# Altium schematic sheet Voltage_Battery_Measure.SchDoc
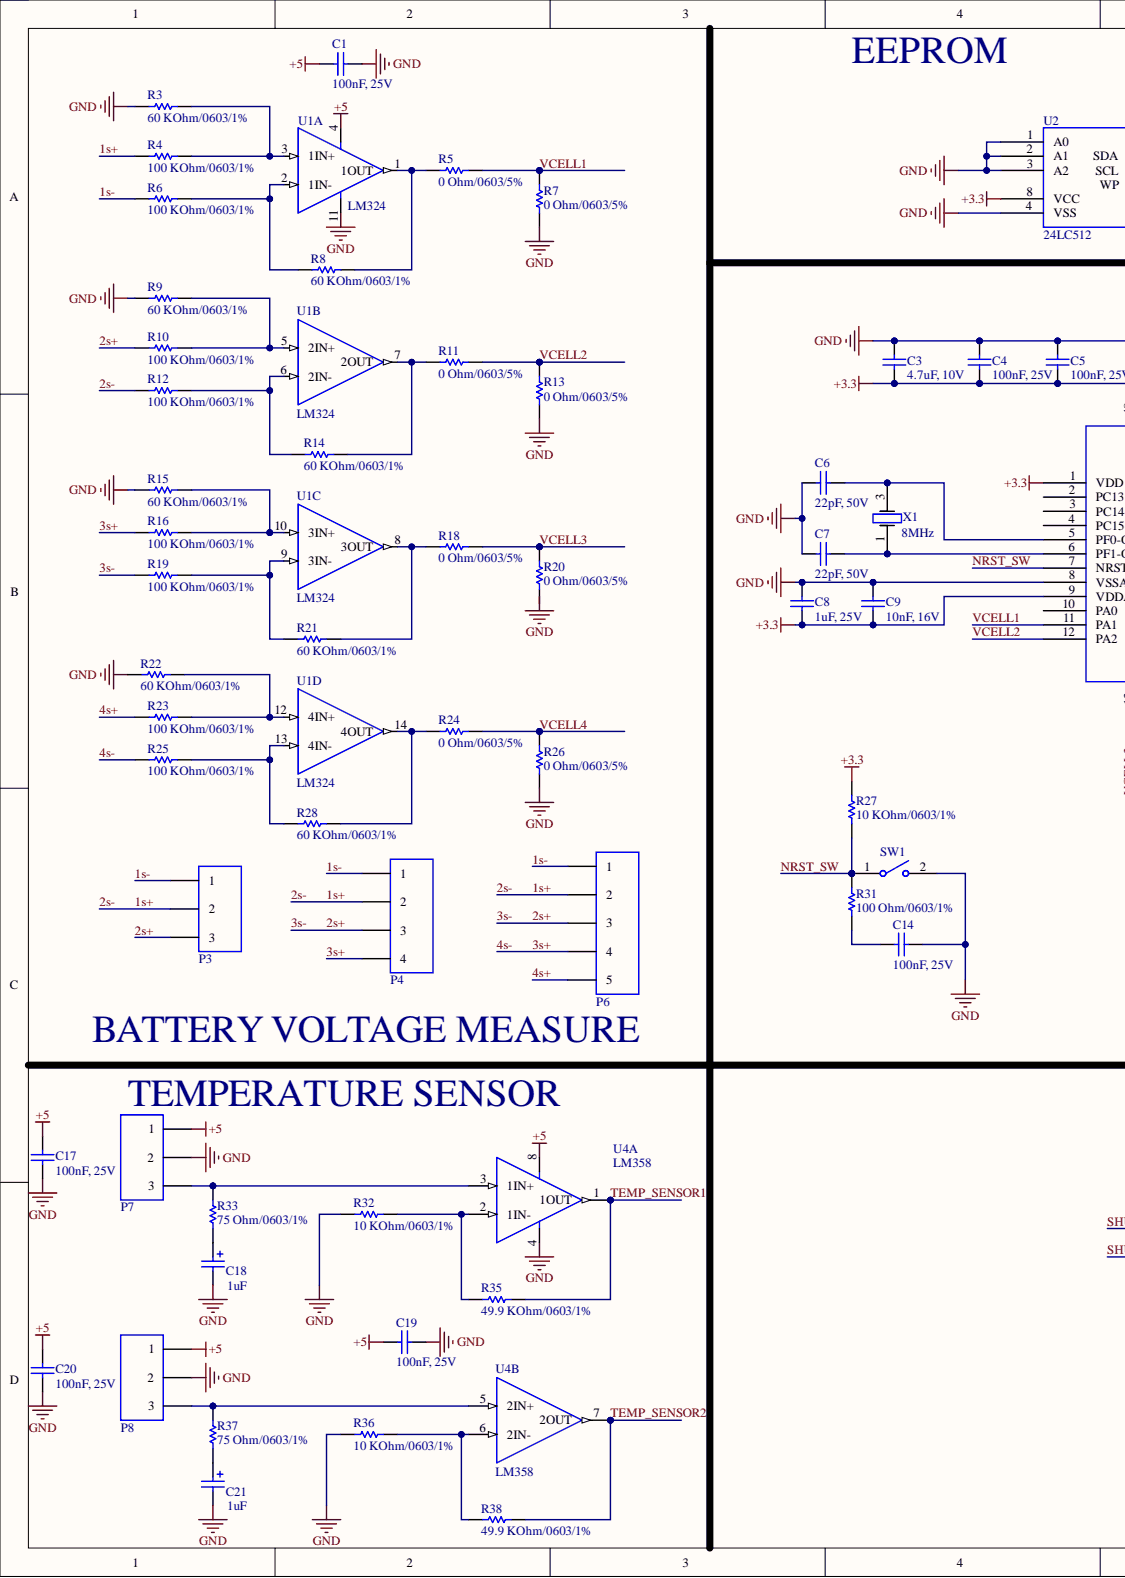
[diagram p0: Voltage_Battery_Measure.SchDoc, left]
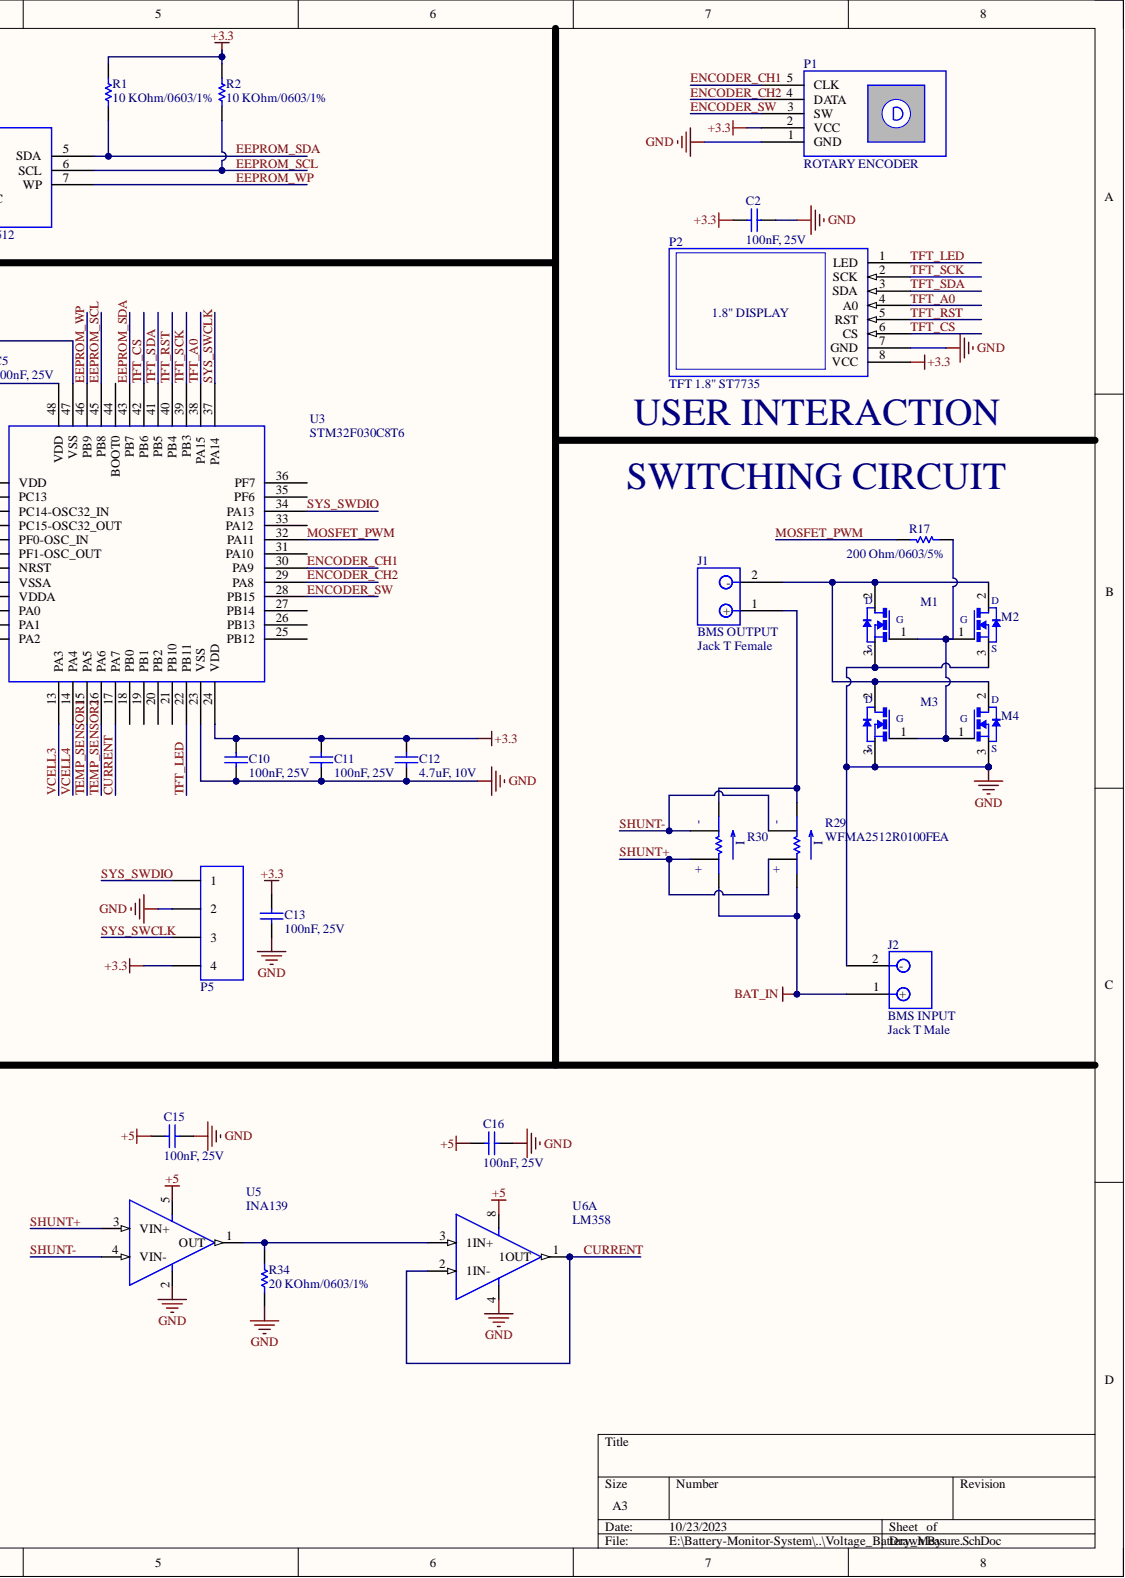
[diagram p1: Voltage_Battery_Measure.SchDoc, right]

## Parts
C1  100nF, 25V  [WCAP-CSGP_0603_H=0.8mm_Reflow]
C2  100nF, 25V  [WCAP-CSGP_0603_H=0.8mm_Reflow]
C3  4.7uF, 10V  [WCAP-CSGP_0603_H=0.8mm_Reflow]
C4  100nF, 25V  [WCAP-CSGP_0603_H=0.8mm_Reflow]
C5  100nF, 25V  [WCAP-CSGP_0603_H=0.8mm_Reflow]
C6  22pF, 50V  [WCAP-CSGP_0603_H=0.8mm_Reflow]
C7  22pF, 50V  [WCAP-CSGP_0603_H=0.8mm_Reflow]
C8  1uF, 25V  [WCAP-CSGP_0603_H=0.8mm_Reflow]
C9  10nF, 16V  [WCAP-CSGP_0603_H=0.8mm_Reflow]
C10  100nF, 25V  [WCAP-CSGP_0603_H=0.8mm_Reflow]
C11  100nF, 25V  [WCAP-CSGP_0603_H=0.8mm_Reflow]
C12  4.7uF, 10V  [WCAP-CSGP_0603_H=0.8mm_Reflow]
C13  100nF, 25V  [WCAP-CSGP_0603_H=0.8mm_Reflow]
C14  100nF, 25V  [WCAP-CSGP_0603_H=0.8mm_Reflow]
C15  100nF, 25V  [WCAP-CSGP_0603_H=0.8mm_Reflow]
C16  100nF, 25V  [WCAP-CSGP_0603_H=0.8mm_Reflow]
C17  100nF, 25V  [WCAP-CSGP_0603_H=0.8mm_Reflow]
C18  1uF  [WCAP-ATG8_D5H11]
C19  100nF, 25V  [WCAP-CSGP_0603_H=0.8mm_Reflow]
C20  100nF, 25V  [WCAP-CSGP_0603_H=0.8mm_Reflow]
C21  1uF  [WCAP-ATG8_D5H11]
J1  BMS OUTPUT  value Jack T Female  [Jack T - FEMALE]
J2  BMS INPUT  value Jack T Male  [Jack T - Male]
M1  AOD472  [TO252-3]  (value not drawn)
M2  AOD472  [TO252-3]  (value not drawn)
M3  AOD472  [TO252-3]  (value not drawn)
M4  AOD472  [TO252-3]  (value not drawn)
P1  Rotary Encoder  [Rotary Encoder]
P2  TFT 1.8" ST7735  [JP8]
P3  Header 3H  [JP3H]  (value not drawn)
P4  Header 4H  [JP4H]  (value not drawn)
P5  Header 4H  [JP4H]  (value not drawn)
P6  Header 5H  [JP5H]  (value not drawn)
P7  Header 3H  [JP3H]  (value not drawn)
P8  Header 3H  [JP3H]  (value not drawn)
R1  value 10 KOhm/0603/1%  [R0603]  (hidden comment: Resistor 10 KOhm 0603 1%)
R2  value 10 KOhm/0603/1%  [R0603]  (hidden comment: Resistor 10 KOhm 0603 1%)
R3  value 60 KOhm/0603/1%  [R0603]  (hidden comment: Resistor 60 KOhm 0603 1%)
R4  value 100 KOhm/0603/1%  [R0603]  (hidden comment: Resistor 100 KOhm 0603 1%)
R5  value 0 Ohm/0603/5%  [R0603]  (hidden comment: Resistor 0 Ohm 0603 5%)
R6  value 100 KOhm/0603/1%  [R0603]  (hidden comment: Resistor 100 KOhm 0603 1%)
R7  value 0 Ohm/0603/5%  [R0603]  (hidden comment: Resistor 0 Ohm 0603 5%)
R8  value 60 KOhm/0603/1%  [R0603]  (hidden comment: Resistor 60 KOhm 0603 1%)
R9  value 60 KOhm/0603/1%  [R0603]  (hidden comment: Resistor 60 KOhm 0603 1%)
R10  value 100 KOhm/0603/1%  [R0603]  (hidden comment: Resistor 100 KOhm 0603 1%)
R11  value 0 Ohm/0603/5%  [R0603]  (hidden comment: Resistor 0 Ohm 0603 5%)
R12  value 100 KOhm/0603/1%  [R0603]  (hidden comment: Resistor 100 KOhm 0603 1%)
R13  value 0 Ohm/0603/5%  [R0603]  (hidden comment: Resistor 0 Ohm 0603 5%)
R14  value 60 KOhm/0603/1%  [R0603]  (hidden comment: Resistor 60 KOhm 0603 1%)
R15  value 60 KOhm/0603/1%  [R0603]  (hidden comment: Resistor 60 KOhm 0603 1%)
R16  value 100 KOhm/0603/1%  [R0603]  (hidden comment: Resistor 100 KOhm 0603 1%)
R17  value 200 Ohm/0603/5%  [R0603]  (hidden comment: Resistor 200 Ohm 0603 5%)
R18  value 0 Ohm/0603/5%  [R0603]  (hidden comment: Resistor 0 Ohm 0603 5%)
R19  value 100 KOhm/0603/1%  [R0603]  (hidden comment: Resistor 100 KOhm 0603 1%)
R20  value 0 Ohm/0603/5%  [R0603]  (hidden comment: Resistor 0 Ohm 0603 5%)
R21  value 60 KOhm/0603/1%  [R0603]  (hidden comment: Resistor 60 KOhm 0603 1%)
R22  value 60 KOhm/0603/1%  [R0603]  (hidden comment: Resistor 60 KOhm 0603 1%)
R23  value 100 KOhm/0603/1%  [R0603]  (hidden comment: Resistor 100 KOhm 0603 1%)
R24  value 0 Ohm/0603/5%  [R0603]  (hidden comment: Resistor 0 Ohm 0603 5%)
R25  value 100 KOhm/0603/1%  [R0603]  (hidden comment: Resistor 100 KOhm 0603 1%)
R26  value 0 Ohm/0603/5%  [R0603]  (hidden comment: Resistor 0 Ohm 0603 5%)
R27  value 10 KOhm/0603/1%  [R0603]  (hidden comment: Resistor 10 KOhm 0603 1%)
R28  value 60 KOhm/0603/1%  [R0603]  (hidden comment: Resistor 60 KOhm 0603 1%)
R29  value WFMA2512R0100FEA  [R2512]  (hidden comment: Current Sense Resistor)
R30  Current Sense Resistor  [R2512]  (value not drawn)
R31  value 100 Ohm/0603/1%  [R0603]  (hidden comment: Resistor 100 Ohm 0603 1%)
R32  value 10 KOhm/0603/1%  [R0603]  (hidden comment: Resistor 10 KOhm 0603 1%)
R33  value 75 Ohm/0603/1%  [R0603]  (hidden comment: Resistor 75 Ohm 0603 1%)
R34  value 20 KOhm/0603/1%  [R0603]  (hidden comment: Resistor 20 KOhm 0603 1%)
R35  value 49.9 KOhm/0603/1%  [R0603]  (hidden comment: Resistor 49.9 KOhm 0603 1%)
R36  value 10 KOhm/0603/1%  [R0603]  (hidden comment: Resistor 10 KOhm 0603 1%)
R37  value 75 Ohm/0603/1%  [R0603]  (hidden comment: Resistor 75 Ohm 0603 1%)
R38  value 49.9 KOhm/0603/1%  [R0603]  (hidden comment: Resistor 49.9 KOhm 0603 1%)
SW1  434123050816  [WS-TASV_L6W3.5H5_4341x30508x6]  (value not drawn)
U1  LM324  [SOIC-14]  (parts A,B,C,D)
U2  value 24LC512  [SOIC-8]  (hidden comment: 24LCxx)
U3  STM32F030C8T6  [48-LQFP]
U4  LM358  [SOIC-8]  (parts A,B)
U5  INA139  [SOT-23]
U6  LM358  [SOIC-8]  (part A)
X1  value 8MHz  [XTAL 3225]  (hidden comment: XTAL)

## Nets
+3.3: C2.2 C3.1 C4.1 C5.1 C8.1 C9.1 C10.2 C11.2 C12.2 C13.2 P1.2(VCC) P2.8(VCC) P5.4 R1.2 R2.2 R27.2 U2.8(VCC) U3.1(VDD) U3.9(VDDA) U3.24(VDD) U3.48(VDD)
+5: C1.2 C15.2 C16.2 C17.2 C19.2 C20.2 P7.1 P8.1 U1.4 U4.8 U5.5 U6.8
BAT_IN: J2.1 R29.1 R30.1
GND: C1.1 C2.1 C3.2 C4.2 C5.2 C6.1 C7.1 C8.2 C9.2 C10.1 C11.1 C12.1 C13.1 C14.1 C15.1 C16.1 C17.1 C18.2 C19.1 C20.1 C21.2 J2.2 M1.3 M2.3 M3.3 M4.3 P1.1(GND) P2.7(GND) P5.2 P7.2 P8.2 R3.2 R7.1 R9.2 R13.1 R15.2 R22.2 R26.1 R32.2 R34.1 R36.2 SW1.2 U1.11 U2.1(A0) U2.2(A1) U2.3(A2) U2.4(VSS) U3.8(VSSA) U3.23(VSS) U3.47(VSS) U4.4 U5.2 U6.4
1s+: P3.2 P4.2 P6.2 R4.1 R12.1
1s-: P3.1 P4.1 P6.1 R6.1
2s+: P3.3 P4.3 P6.3 R10.1 R19.1
3s+: P4.4 P6.4 R16.1 R25.1
4s+: P6.5 R23.1
CURRENT: U3.17(PA7) U6.1(1OUT) U6.2(1IN-)
EEPROM_SCL: R2.1 U2.6(SCL) U3.45(PB8)
EEPROM_SDA: R1.1 U2.5(SDA) U3.43(PB7)
EEPROM_WP: U2.7(WP) U3.46(PB9)
ENCODER_CH1: P1.5(CLK) U3.30(PA9)
ENCODER_CH2: P1.4(DATA) U3.29(PA8)
ENCODER_SW: P1.3(SW) U3.28(PB15)
MOSFET_PWM: R17.2 U3.32(PA11)
NRST_SW: R27.1 R31.2 SW1.1 U3.7(NRST)
SHUNT+: R29.1 R30.1 U5.3(VIN+)
SHUNT-: R29.2 R30.2 U5.4(VIN-)
SYS_SWCLK: P5.3 U3.37(PA14)
SYS_SWDIO: P5.1 U3.34(PA13)
TEMP_SENSOR1: R35.2 U3.15(PA5) U4.1(1OUT)
TEMP_SENSOR2: R38.2 U3.16(PA6) U4.7(2OUT)
TFT_A0: P2.4(A0) U3.38(PA15)
TFT_CS: P2.6(CS) U3.42(PB6)
TFT_LED: P2.1(LED) U3.22(PB11)
TFT_RST: P2.5(RST) U3.40(PB4)
TFT_SCK: P2.2(SCK) U3.39(PB3)
TFT_SDA: P2.3(SDA) U3.41(PB5)
VCELL1: R5.2 R7.2 U3.11(PA1)
VCELL2: R11.2 R13.2 U3.12(PA2)
VCELL3: R18.2 R20.2 U3.13(PA3)
VCELL4: R24.2 R26.2 U3.14(PA4)
NetC6_2: C6.2 U3.5(PF0-OSC_IN) X1.3
NetC7_2: C7.2 U3.6(PF1-OSC_OUT) X1.1
NetC14_2: C14.2 R31.1
NetC18_1: C18.1 R33.1
NetC21_1: C21.1 R37.1
NetJ1_1: J1.1 R29.2 R30.2
NetJ1_2: J1.2 M1.2 M2.2 M3.2 M4.2
NetM1_1: M1.1 M2.1 M3.1 M4.1 R17.1
NetP7_3: P7.3 R33.2 U4.3(1IN+)
NetP8_3: P8.3 R37.2 U4.5(2IN+)
NetR3_1: R3.1 R4.2 U1.3(1IN+)
NetR5_1: R5.1 R8.2 U1.1(1OUT)
NetR6_2: R6.2 R8.1 U1.2(1IN-)
NetR9_1: R9.1 R10.2 U1.5(2IN+)
NetR11_1: R11.1 R14.2 U1.7(2OUT)
NetR12_2: R12.2 R14.1 U1.6(2IN-)
NetR15_1: R15.1 R16.2 U1.10(3IN+)
NetR18_1: R18.1 R21.2 U1.8(3OUT)
NetR19_2: R19.2 R21.1 U1.9(3IN-)
NetR22_1: R22.1 R23.2 U1.12(4IN+)
NetR24_1: R24.1 R28.2 U1.14(4OUT)
NetR25_2: R25.2 R28.1 U1.13(4IN-)
NetR32_1: R32.1 R35.1 U4.2(1IN-)
NetR34_2: R34.2 U5.1(OUT) U6.3(1IN+)
NetR36_1: R36.1 R38.1 U4.6(2IN-)

Not connected: R20 1; U3 2(PC13) 3(PC14-OSC32_IN) 4(PC15-OSC32_OUT) 10(PA0) 18(PB0) 19(PB1) 20(PB2) 21(PB10) 25(PB12) 26(PB13) 27(PB14) 31(PA10) 33(PA12) 35(PF6) 36(PF7) 44(BOOT0)

## Library data of the parts
C1 C2 C4 C5 C10 C11 C13-C17 C19 C20 = 885012206071: WCAP-CSGP Multilayer Ceramic Chip Capacitor, General Purpose, size 0603, X7R, 100nF, 25V; Manufacturer: Wurth Elektronik; Capacitance Tolerance: +/-10%; Dissipation Factor: #NAME?; Isolation Resistance: >=5GOhm; Rated Voltage: 25VDC; Technical Reference: X7R0603104K025DFCT10000; Capacitance: 100nF; Ceramic Type: X7R; Component Kind: Standard; ComponentLink1Description: Manufacturer; ComponentLink1URL: http://www.we-online.de; ComponentLink2Description: Datasheet; ComponentLink2URL: https://www.we-online.com/catalog/datasheet/885012206071.pdf; Height: 0.8mm; Library Name: Altium_WCAP-CSGP (rev20a).IntLib; Manufacturer Part Number: 885012206071; Mounting Technology: SMT; Operating Temperature: -55 to +125degC; Packaging: Tape and Reel; Published Date: May 2020; Size: 0603
C3 C12 = 885012106012: WCAP-CSGP Multilayer Ceramic Chip Capacitor, General Purpose, size 0603, X5R, 4.7uF, 10V; Manufacturer: Wurth Elektronik; Capacitance Tolerance: +/-20%; Dissipation Factor: #NAME?; Isolation Resistance: >=0.02GOhm; Rated Voltage: 10VDC; Technical Reference: X5R0603475M010DFCT10000; Capacitance: 4.7uF; Ceramic Type: X5R; Component Kind: Standard; ComponentLink1Description: Manufacturer; ComponentLink1URL: http://www.we-online.de; ComponentLink2Description: Datasheet; ComponentLink2URL: https://www.we-online.com/catalog/datasheet/885012106012.pdf; Height: 0.8mm; Library Name: Altium_WCAP-CSGP (rev20a).IntLib; Manufacturer Part Number: 885012106012; Mounting Technology: SMT; Operating Temperature: -55 to +85degC; Packaging: Tape and Reel; Published Date: May 2020; Size: 0603
C6 C7 = 885012006053: WCAP-CSGP Multilayer Ceramic Chip Capacitor, General Purpose, size 0603, NP0, 22pF, 50V; Manufacturer: Wurth Elektronik; Capacitance Tolerance: +/-5%; Dissipation Factor: Q>=400+20C; Isolation Resistance: >=10GOhm; Rated Voltage: 50VDC; Technical Reference: NP00603220J050DFCT10000; Capacitance: 22pF; Ceramic Type: NP0; Component Kind: Standard; ComponentLink1Description: Manufacturer; ComponentLink1URL: http://www.we-online.de; ComponentLink2Description: Datasheet; ComponentLink2URL: https://www.we-online.com/catalog/datasheet/885012006053.pdf; Height: 0.8mm; Library Name: Altium_WCAP-CSGP (rev20a).IntLib; Manufacturer Part Number: 885012006053; Mounting Technology: SMT; Operating Temperature: -55 to +125degC; Packaging: Tape and Reel; Published Date: May 2020; Size: 0603
C8 = 885012106022: WCAP-CSGP Multilayer Ceramic Chip Capacitor, General Purpose, size 0603, X5R, 1uF, 25V; Manufacturer: Wurth Elektronik; Capacitance Tolerance: +/-20%; Dissipation Factor: #NAME?; Isolation Resistance: >=0.5GOhm; Rated Voltage: 25VDC; Technical Reference: X5R0603105M025DFCT10000; Capacitance: 1uF; Ceramic Type: X5R; Component Kind: Standard; ComponentLink1Description: Manufacturer; ComponentLink1URL: http://www.we-online.de; ComponentLink2Description: Datasheet; ComponentLink2URL: https://www.we-online.com/catalog/datasheet/885012106022.pdf; Height: 0.8mm; Library Name: Altium_WCAP-CSGP (rev20a).IntLib; Manufacturer Part Number: 885012106022; Mounting Technology: SMT; Operating Temperature: -55 to +85degC; Packaging: Tape and Reel; Published Date: May 2020; Size: 0603
C9 = 885012206040: WCAP-CSGP Multilayer Ceramic Chip Capacitor, General Purpose, size 0603, X7R, 10nF, 16V; Manufacturer: Wurth Elektronik; Capacitance Tolerance: +/-10%; Dissipation Factor: #NAME?; Isolation Resistance: >=10GOhm; Rated Voltage: 16VDC; Technical Reference: X7R0603103K016DFCT10000; Capacitance: 10nF; Ceramic Type: X7R; Component Kind: Standard; ComponentLink1Description: Manufacturer; ComponentLink1URL: http://www.we-online.de; ComponentLink2Description: Datasheet; ComponentLink2URL: https://www.we-online.com/catalog/datasheet/885012206040.pdf; Height: 0.8mm; Library Name: Altium_WCAP-CSGP (rev20a).IntLib; Manufacturer Part Number: 885012206040; Mounting Technology: SMT; Operating Temperature: -55 to +125degC; Packaging: Tape and Reel; Published Date: May 2020; Size: 0603
C18 C21 = 860010672005: WCAP-ATG8 Aluminum Electrolytic Capacitor, Radial THT, D5xH11mm, 1uF, 50V; Manufacturer: Wurth Elektronik; Capacitance Tolerance: +/-20%; Dissipation Factor: 10%; Endurance: 2000h; Leakage Current: 3uA; Ripple Current: 17mA; Technical Reference: ATBC110010M050DSPA3B000; Category: Aluminum Electrolytic Capacitors; Component Kind: Standard; ComponentLink1Description: Manufacturer Link; ComponentLink1URL: https://www.we-online.com/redexpert/article/860010672005?ad; ComponentLink2Description: Datasheet; ComponentLink2URL: https://www.we-online.com/redexpert/spec/860010672005?ad; Diameter: 5mm; Height: 11mm; Library Name: Altium_WCAP-ATG8 (rev22a).IntLib; Manufacturer Part Number: 860010672005; Match Code: WCAP-ATG8; Mount: Through Hole; Operating Temperature Max: 85°C; Operating Temperature Min: -40°C; Packaging: Ammo Pack; Rated Voltage (DC): 50V; Value: 1uF
J1 = Jack T Female: Jack T 120A; Component Kind: Standard; ComponentLink1Description: Buy; ComponentLink1URL: https://www.thegioiic.com/jack-cam-chu-t-duc; Library Name: Giang's Libs.IntLib
J2 = Jack T Male: Jack T 120A; Component Kind: Standard; ComponentLink1Description: Buy; ComponentLink1URL: https://www.thegioiic.com/jack-cam-chu-t-duc; Library Name: Giang's Libs.IntLib
M1-M4 = AOD472: MOSFET 25V 55A N-Channel; Component Kind: Standard; ComponentLink1Description: Buy; ComponentLink1URL: https://www.thegioiic.com/aod472-mosfet-kenh-n-25v-55a-to-252-3; Value: AOD472; Library Name: Giang_Transistor.IntLib
P1 = MODULE ROTARY ENCODER: KY-040; Component Kind: Standard; ComponentLink1Description: Buy; ComponentLink1URL: https://www.thegioiic.com/ky-040-mach-dieu-khien-goc-quay-encoder; Value: ROTARY ENCODER; Library Name: Giang's Libs.IntLib
P2 = TFT 1.8" ST7735: LCD 1.8inch 160x128 TFT ST7735; Component Kind: Standard; ComponentLink1Description: Buy; ComponentLink1URL: https://www.thegioiic.com/lcd-1-8inch-160x128-tft-st7735-giao-tiep-spi; Value: TFT 1.8" ST7735; Library Name: Giang's Libs.IntLib
P3 P7 P8 = Header 3H: Header, 3-Pin, Right Angle; Component Kind: Standard; LatestRevisionDate: 17-Jul-2002; LatestRevisionNote: Re-released for DXP Platform.; Library Name: PIF_Library.IntLib; Value: Header 3H; Publisher: Altium Limited
P4 P5 = Header 4H: Header, 4-Pin, Right Angle; Component Kind: Standard; LatestRevisionDate: 17-Jul-2002; LatestRevisionNote: Re-released for DXP Platform.; Library Name: PIF_Library.IntLib; Value: Header 4H; Publisher: Altium Limited
P6 = Header 5H: Header, 5-Pin, Right Angle; Component Kind: Standard; LatestRevisionDate: 17-Jul-2002; LatestRevisionNote: Re-released for DXP Platform.; Library Name: PIF_Library.IntLib; Value: Header 5H; Publisher: Altium Limited
R1 R2 R27 R32 R36 = Resistor 10 KOhm 0603 1%: Code 103, 1/10W; Component Kind: Standard; ComponentLink1Description: Buy; ComponentLink1URL: https://www.thegioiic.com/dien-tro-10-kohm-0603-1-; Library Name: Giang_Resistor.IntLib
R3 R8 R9 R14 R15 R21 R22 R28 = Resistor 60 KOhm 0603 1%: Code 603, 1/10W; Component Kind: Standard; ComponentLink1Description: Buy; ComponentLink1URL: https://www.thegioiic.com/dien-tro-60-kohm-0603-1-; Library Name: Giang_Resistor.IntLib
R4 R6 R10 R12 R16 R19 R23 R25 = Resistor 100 KOhm 0603 1%: Code 104, 1/10W; Component Kind: Standard; ComponentLink1Description: Buy; ComponentLink1URL: https://www.thegioiic.com/dien-tro-100-kohm-0603-1-; Library Name: Giang_Resistor.IntLib
R5 R7 R11 R13 R18 R20 R24 R26 = Resistor 0 Ohm 0603 5%: Code 0R, 1/10W; Component Kind: Standard; ComponentLink1Description: Buy; ComponentLink1URL: https://www.thegioiic.com/dien-tro-0-ohm-0603-5-; Library Name: Giang_Resistor.IntLib
R17 = Resistor 200 Ohm 0603 5%: Code 201, 1/10W; Value: 200 Ohm/0603/5%; Component Kind: Standard; ComponentLink1Description: Buy; ComponentLink1URL: https://www.thegioiic.com/dien-tro-200-ohm-0603-5-; Library Name: Giang_Resistor.IntLib
R29 = Current Sense Resistor: Shunt Resistor; Component Kind: Standard; ComponentLink1Description: Buy; ComponentLink1URL: https://linhkien.cxt.vn/3121-wfma2512r0100fea-tro-001-ohms-1-3w.html; Library Name: Giang_Resistor.IntLib
R30 = Current Sense Resistor: Shunt Resistor; Value: R; Component Kind: Standard; ComponentLink1Description: Buy; ComponentLink1URL: https://linhkien.cxt.vn/3121-wfma2512r0100fea-tro-001-ohms-1-3w.html; Library Name: Giang_Resistor.IntLib
R31 = Resistor 100 Ohm 0603 1%: Code 1000, 1/10W; Value: 100 Ohm/0603/1%; Component Kind: Standard; ComponentLink1Description: Buy; ComponentLink1URL: https://www.thegioiic.com/dien-tro-100-ohm-0603-1-; Library Name: Giang_Resistor.IntLib
R33 R37 = Resistor 75 Ohm 0603 1%: Code 750, 1/10W; Component Kind: Standard; ComponentLink1Description: Buy; ComponentLink1URL: https://www.thegioiic.com/dien-tro-75-ohm-0603-1-; Library Name: Giang_Resistor.IntLib
R34 = Resistor 20 KOhm 0603 1%: Code 203,1/10W; Component Kind: Standard; ComponentLink1Description: Buy; ComponentLink1URL: https://www.thegioiic.com/dien-tro-20-kohm-0603-1-; Library Name: Giang_Resistor.IntLib
R35 R38 = Resistor 49.9 KOhm 0603 1%: Code (4992, 68C), 1/10W; Component Kind: Standard; ComponentLink1Description: Buy; ComponentLink1URL: https://www.thegioiic.com/dien-tro-49-9-kohm-0603-1-; Library Name: Giang_Resistor.IntLib
SW1 = 434123050816: WS-TASV J-Bend SMT Tact Switch, 12VDC, 50mA, 160g, height 5mm; Manufacturer: Wurth Elektronik; Actuator Color: Black; Electrical Life: 50000Cycles; Rated Voltage: 12V; Washable: No; Component Kind: Standard; ComponentLink1Description: Manufacturer Link; ComponentLink1URL: http://www.we-online.de; ComponentLink2Description: Datasheet; ComponentLink2URL: https://www.we-online.com/catalog/datasheet/434123050816.pdf; Contact Material: Stainless Steel; Contact Plating: Silver; Height: 5mm; Length: 6mm; Library Name: Altium_WS-TASV (rev22a).IntLib; Manufacturer Part Number: 434123050816; Mounting Technology: SMT; Operating Temperature max.: 85C; Operating Temperature min.: -40C; Operation Force: 160g; Packaging: Tape and Reel; Rated Current: 50mA; Terminal Material: Copper Alloy; Terminal Plating: Silver; Width: 3.5mm
U1 = LM324: OPAMP General Purpose Amplifier 4 Circuit 1.2MHz; Component Kind: Standard; ComponentLink1Description: Buy; ComponentLink1URL: https://www.thegioiic.com/lm324dr-ic-opamp-general-purpose-amplifier-4-circuit-1-2mhz-14-soic; Value: LM324; Library Name: Giang_OPAMP.IntLib
U2 = 24LCxx: EEPROM; Component Kind: Standard; Library Name: Giang's Libs.IntLib
U4 U6 = LM358: General Purpose Amplifier 2 Circuit 1.1MHz; Component Kind: Standard; Value: LM358; Library Name: Giang_OPAMP.IntLib
U5 = INA139: High-Side Measurement Current Shunt Monitor; Component Kind: Standard; Value: INA139; Library Name: Giang_OPAMP.IntLib
U3 = STM32F030C8T6: Component Kind: Standard; ComponentLink1Description: Buy; Value: STM32F030C8T6; Library Name: Giang_MCU.IntLib
X1 = XTAL: Component Kind: Standard; Library Name: MiclsLib.IntLib
